# Altium schematic sheet 403.5MHz_LNA.SchDoc(403.5MHz_LNA)
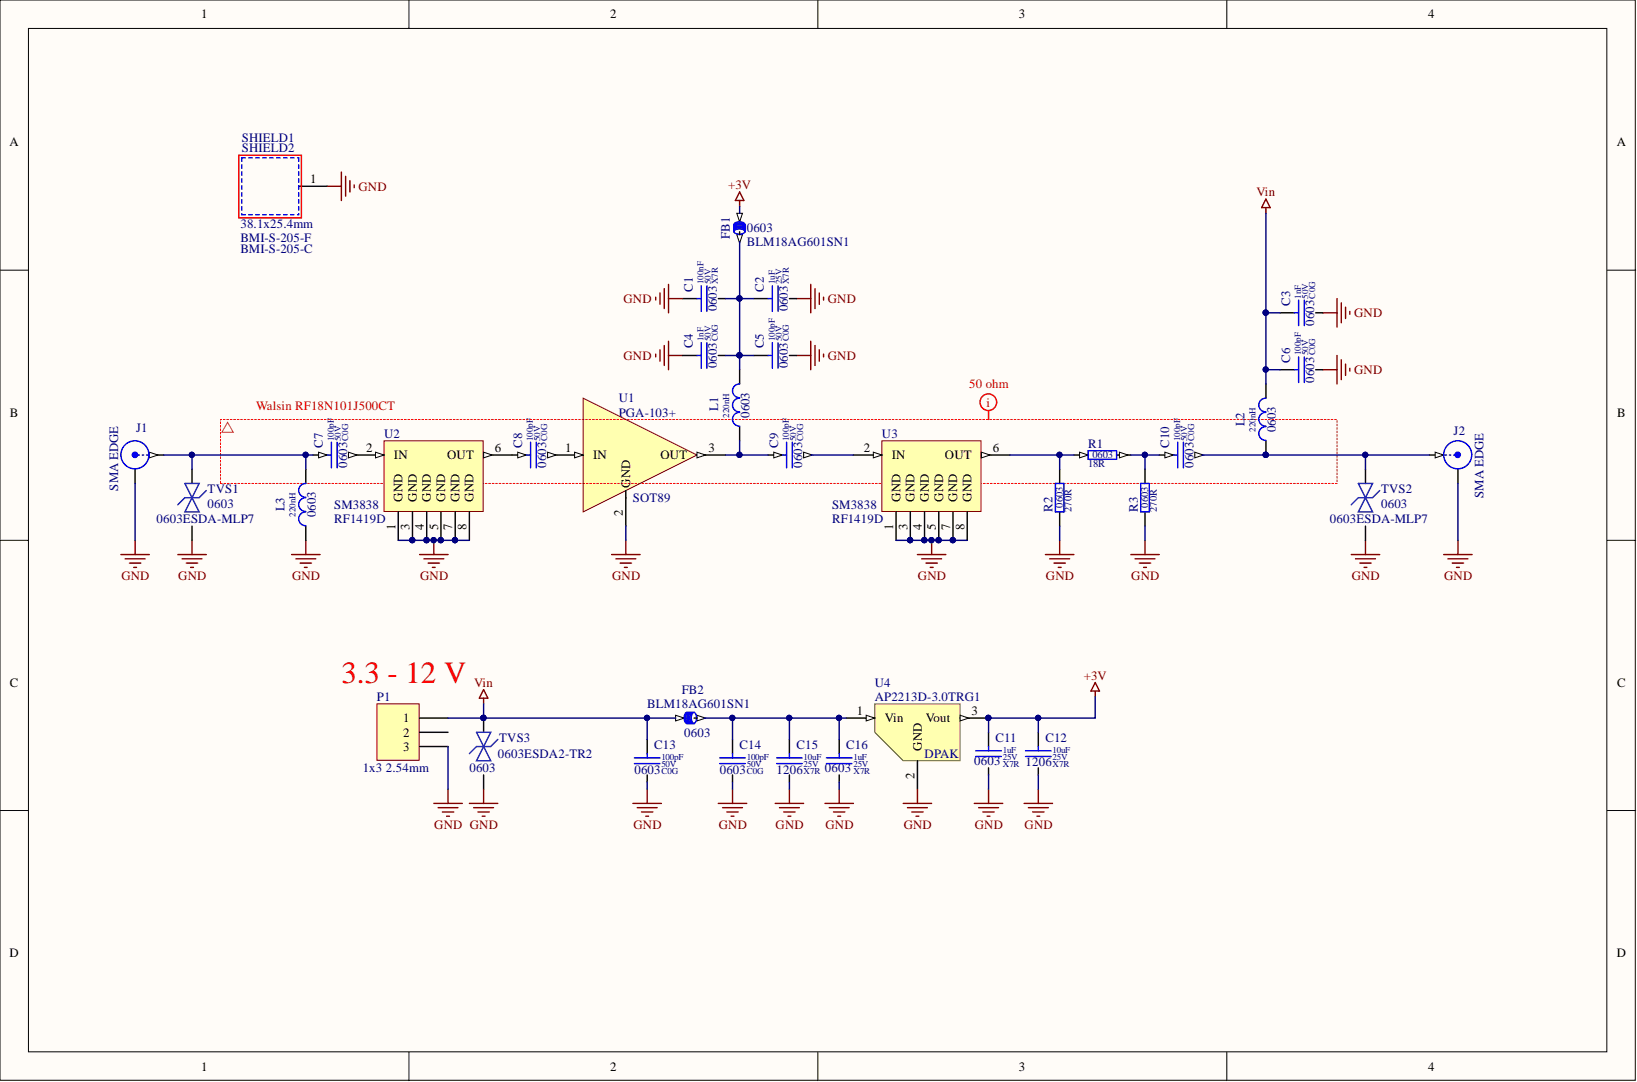
[diagram p0: 403.5MHz_LNA.SchDoc]

## Parts
C1  value 100nF  Voltage: 50V  SCH_Footprint: 0603  Dielectric: X7R  [C_0603_1608]  (hidden comment: Ceramic X7R)
C2  value 1uF  Voltage: 25V  SCH_Footprint: 0603  Dielectric: X7R  [C_0603_1608]  (hidden comment: Ceramic X7R)
C3  value 1nF  Voltage: 50V  SCH_Footprint: 0603  Dielectric: C0G  [C_0603_1608]  (hidden comment: Ceramic C0G)
C4  value 1nF  Voltage: 50V  SCH_Footprint: 0603  Dielectric: C0G  [C_0603_1608]  (hidden comment: Ceramic C0G)
C5  value 100pF  Voltage: 50V  SCH_Footprint: 0603  Dielectric: C0G  [C_0603_1608]  (hidden comment: Ceramic C0G)
C6  value 100pF  Voltage: 50V  SCH_Footprint: 0603  Dielectric: C0G  [C_0603_1608]  (hidden comment: Ceramic C0G)
C7  value 100pF  Voltage: 50V  SCH_Footprint: 0603  Dielectric: C0G  [C_0603_1608]  (hidden comment: Ceramic C0G)
C8  value 100pF  Voltage: 50V  SCH_Footprint: 0603  Dielectric: C0G  [C_0603_1608]  (hidden comment: Ceramic C0G)
C9  value 100pF  Voltage: 50V  SCH_Footprint: 0603  Dielectric: C0G  [C_0603_1608]  (hidden comment: Ceramic C0G)
C10  value 100pF  Voltage: 50V  SCH_Footprint: 0603  Dielectric: C0G  [C_0603_1608]  (hidden comment: Ceramic C0G)
C11  value 1uF  Voltage: 25V  SCH_Footprint: 0603  Dielectric: X7R  [C_0603_1608]  (hidden comment: Ceramic X7R)
C12  value 10uF  Voltage: 25V  SCH_Footprint: 1206  Dielectric: X7R  [C_1206_3216]  (hidden comment: Ceramic X7R)
C13  value 100pF  Voltage: 50V  SCH_Footprint: 0603  Dielectric: C0G  [C_0603_1608]  (hidden comment: Ceramic C0G)
C14  value 100pF  Voltage: 50V  SCH_Footprint: 0603  Dielectric: C0G  [C_0603_1608]  (hidden comment: Ceramic C0G)
C15  value 10uF  Voltage: 25V  SCH_Footprint: 1206  Dielectric: X7R  [C_1206_3216]  (hidden comment: Ceramic X7R)
C16  value 1uF  Voltage: 25V  SCH_Footprint: 0603  Dielectric: X7R  [C_0603_1608]  (hidden comment: Ceramic X7R)
FB1  value BLM18AG601SN1  SCH_Footprint: 0603  [FB_0603_1608]  (hidden comment: Ferrite bead)
FB2  value BLM18AG601SN1  SCH_Footprint: 0603  [FB_0603_1608]  (hidden comment: Ferrite bead)
J1  SMA EDGE  [J_SMA_EDGE_6.25]
J2  SMA EDGE  [J_SMA_EDGE_6.25]
L1  value 220nH  SCH_Footprint: 0603  [L_0603_1608]  (hidden comment: Inductor)
L2  value 220nH  SCH_Footprint: 0603  [L_0603_1608]  (hidden comment: Inductor)
L3  value 220nH  SCH_Footprint: 0603  [L_0603_1608]  (hidden comment: Inductor)
P1  SCH_Footprint: 1x3 2.54mm  [P_HEADER_1x3_2.54]  (hidden comment: Goldpin)
R1  value 18R  SCH_Footprint: 0603  [R_NC_0603_1608]  (hidden comment: Resistor)
R2  value 270R  SCH_Footprint: 0603  [R_NC_0603_1608]  (hidden comment: Resistor)
R3  value 270R  SCH_Footprint: 0603  [R_NC_0603_1608]  (hidden comment: Resistor)
SHIELD1  value BMI-S-205-C  SCH_Footprint: 38.1x25.4mm  [BMI-S-205-C]  (hidden comment: EMI Shield Cover)
SHIELD2  value BMI-S-205-F  SCH_Footprint: 38.1x25.4mm  [BMI-S-205-F]  (hidden comment: EMI Shield)
TVS1  value 0603ESDA-MLP7  SCH_Footprint: 0603  [R_0603_1608]  (hidden comment: TVS bidirectional)
TVS2  value 0603ESDA-MLP7  SCH_Footprint: 0603  [R_0603_1608]  (hidden comment: TVS bidirectional)
TVS3  0603ESDA2  value 0603ESDA2-TR2  SCH_Footprint: 0603  [R_0603_1608]
U1  PGA103+  value PGA-103+  SCH_Footprint: SOT89  [SOT89_TO-243AA_N]
U2  RF1419D  SCH_Footprint: SM3838  [SAW_QCC8B_SM3838-8_3.8x3.8]
U3  RF1419D  SCH_Footprint: SM3838  [SAW_QCC8B_SM3838-8_3.8x3.8]
U4  AP2213D-3.0  value AP2213D-3.0TRG1  SCH_Footprint: DPAK  [DPAK_TO252-3_SC63_M]

## Nets
+3V: C11.1 C12.1 FB1.1 U4.3
GND: C1.1 C2.2 C3.2 C4.1 C5.2 C6.2 C11.2 C12.2 C13.2 C14.2 C15.2 C16.2 J1.2 J2.2 L3.1 P1.3 R2.1 R3.1 SHIELD2.1 TVS1.2 TVS2.2 TVS3.2 U1.2 U2.1(GND) U2.3(GND) U2.4(GND) U2.5(GND) U2.7(GND) U2.8(GND) U3.1(GND) U3.3(GND) U3.4(GND) U3.5(GND) U3.7(GND) U3.8(GND) U4.2
Vin: C3.1 C6.1 C13.1 FB2.1 L2.2 P1.1 TVS3.1
NetC1_2: C1.2 C2.1 C4.2 C5.1 FB1.2 L1.2
NetC7_1: C7.1 J1.1 L3.2 TVS1.1
NetC7_2: C7.2 U2.2(IN)
NetC8_1: C8.1 U2.6(OUT)
NetC8_2: C8.2 U1.1
NetC9_1: C9.1 L1.1 U1.3
NetC9_2: C9.2 U3.2(IN)
NetC10_1: C10.1 R1.2 R3.2
NetC10_2: C10.2 J2.1 L2.1 TVS2.1
NetC14_1: C14.1 C15.1 C16.1 FB2.2 U4.1
NetR1_1: R1.1 R2.2 U3.6(OUT)
N00003:

Not connected: P1 2

## Library data of the parts
C1 = C_100nF_50V_X7R_0603_5: Capacitor; Manufacturer Part Number: CL10B104JB8NNNC; Manufacturer: Samsung; Tolerance (%): 5; Database Table Name: Capacitors; Supplier Part Number 1: CL10B104JB8NNNC; Supplier 5: Digi-Key; Supplier 4: Mouser; Supplier 3: Farnell; Supplier 2: RS Components; Supplier 1: TME; Part Number: C_100nF_50V_X7R_0603_5; Library Name: Library.DBLib; HelpURL: http://www.tme.eu/pl/Document/4a42202b32dab16128fe107dd69598cc/samsung-chip-cap.pdf; Component Kind: Standard
C2 C11 C16 = C_1uF_25V_X7R_0603_10: Capacitor; Manufacturer Part Number: CL10B105KA8NNNC; Manufacturer: Samsung; Tolerance (%): 10; Database Table Name: Capacitors; Supplier Part Number 1: CL10B105KA8NNNC; Supplier 5: Digi-Key; Supplier 4: Mouser; Supplier 3: Farnell; Supplier 2: RS Components; Supplier 1: TME; Part Number: C_1uF_25V_X7R_0603_10; Library Name: Library.DBLib; HelpURL: http://www.tme.eu/pl/Document/4a42202b32dab16128fe107dd69598cc/samsung-chip-cap.pdf; Component Kind: Standard
C3 C4 = C_1nF_50V_C0G_0603_5: Capacitor; Manufacturer Part Number: CL10C102JB8NNNC; Manufacturer: Samsung; Tolerance (%): 5; Database Table Name: Capacitors; Supplier Part Number 1: CL10C102JB8NNNC; Supplier 5: Digi-Key; Supplier 4: Mouser; Supplier 3: Farnell; Supplier 2: RS Components; Supplier 1: TME; Part Number: C_1nF_50V_C0G_0603_5; Library Name: Library.DBLib; HelpURL: http://www.tme.eu/pl/Document/7da762c1dbaf553c64ad9c40d3603826/mlcc_samsung.pdf; Component Kind: Standard
C5-C10 C13 C14 = C_100pF_50V_C0G_0603_5: Capacitor; Manufacturer Part Number: RF18N101J500CT; Manufacturer: Walsin; Tolerance (%): 5; Database Table Name: Capacitors; Supplier 5: Digi-Key; Supplier 4: Mouser; Supplier 3: Farnell; Supplier 2: RS Components; Supplier 1: TME; Part Number: C_100pF_50V_C0G_0603_5; Library Name: Library.DBLib; HelpURL: https://pl.mouser.com/datasheet/2/210/Microwave_Caps-1535561.pdf; Component Kind: Standard
C12 C15 = C_10uF_25V_X7R_1206_10: Capacitor; Manufacturer Part Number: CL31B106KAHNNNE; Manufacturer: Samsung Electro-Mechanics; Tolerance (%): 10; Database Table Name: Capacitors; Supplier Part Number 1: CL31B106KAHNNNE; Supplier 5: Digi-Key; Supplier 4: Mouser; Supplier 3: Farnell; Supplier 2: RS Components; Supplier 1: TME; Part Number: C_10uF_25V_X7R_1206_10; Library Name: Library.DBLib; HelpURL: http://www.tme.eu/pl/Document/4a42202b32dab16128fe107dd69598cc/samsung-chip-cap.pdf; Component Kind: Standard
FB1 FB2 = FB_BLM18AG601SN1: Manufacturer Part Number: BLM18AG601SN1D; Manufacturer: Murata; Resistance: 600R; Database Table Name: Inductors; Current: 0A5; Supplier Part Number 5: 490-1014-1-ND; Supplier Part Number 4: 81-BLM11A601S; Supplier Part Number 3: 1515679; Supplier Part Number 2: 7241299P; Supplier 5: Digi-Key; Supplier 4: Mouser; Supplier 3: Farnell; Supplier 2: RS Components; Supplier 1: TME; Part Number: FB_BLM18AG601SN1; Library Name: Library.DBLib; HelpURL: http://datasheet.octopart.com/BLM18AG601SN1D-Murata-datasheet-11743096.pdf; Description: Ferrite Bead; Component Kind: Standard
J1 J2 = J_SMA_EDGE_6.25: SMA connector; Manufacturer: Adam Tech; Value: RF2-143-T-17-50-G; Database Table Name: Connectors; Supplier Part Number 1: RF2-143-T-17-50-G; Supplier 5: Digi-Key; Supplier 4: Mouser; Supplier 3: Farnell; Supplier 2: RS Components; Supplier 1: TME; SCH_Footprint: SMA EDGE; Part Number: J_SMA_EDGE_6.25; Manufacturer Part Number: RF2-143-T-17-50-G; Library Name: Library.DBLib; HelpURL: http://www.tme.eu/pl/Document/5895dbb620da9c3499391e7670c4adea/RF2-143-T-17-50-G.pdf; Component Type: Standard; Component Kind: Standard
L1-L3 = L_220nH_120mA_0603: Induction; Manufacturer Part Number: LQW18ANR22G00D; Manufacturer: Murata; Resistance: 2.5R; Database Table Name: Inductors; Current: 120mA; Supplier Part Number 5: 490-6931-1-ND; Supplier Part Number 4: 81-LQW18ANR22G00D; Supplier Part Number 3: 9528059; Supplier Part Number 2: 7242872; Supplier Part Number 1: LQW18ANR22G00D; Supplier 5: Digi-Key; Supplier 4: Mouser; Supplier 3: Farnell; Supplier 2: RS Components; Supplier 1: TME; Part Number: L_220nH_120mA_0603; Library Name: Library.DBLib; HelpURL: https://datasheet.octopart.com/LQW18ANR22G00D-Murata-datasheet-11553032.pdf; Component Kind: Standard
P1 = P_HEADER_1x3_2.54: Header, 3-Pin; Manufacturer: Connfly; Value: DS1021-1*3SF1-1; Database Table Name: Connectors; Supplier Part Number 1: ZL201-03G; Supplier 5: Digi-Key; Supplier 4: Mouser; Supplier 3: Farnell; Supplier 2: RS Components; Supplier 1: TME; Part Number: P_HEADER_1x3_2.54; Manufacturer Part Number: DS1021-1*3SF1-1; Library Name: Library.DBLib; HelpURL: http://www.tme.eu/en/Document/cc301e58a5da78cb543a94b5a5b7f06a/DS1021.pdf; Component Type: Standard; Component Kind: Standard
R1-R3 = R_GENERIC_0603: Database Table Name: Resistors; Supplier 5: Digi-Key; Supplier 4: Mouser; Supplier 3: Farnell; Supplier 2: RS Components; Supplier 1: TME; Part Number: R_GENERIC_0603; Library Name: Library.DBLib; Component Kind: Standard
SHIELD1 = SHIELD_BMI-S-205-C: Shield, 1 pad; Manufacturer: Laird; Database Table Name: Passive Components; Supplier Part Number 5: 903-1017-ND; Supplier Part Number 4: 739-BMIS-205-C; Supplier Part Number 3: 2497722; Supplier 5: Digi-Key; Supplier 4: Mouser; Supplier 3: Farnell; Supplier 2: RS Components; Supplier 1: TME; Part Number: SHIELD_BMI-S-205-C; Manufacturer Part Number: BMI-S-205-C; Library Name: Library.DBLib; HelpURL: https://assets.lairdtech.com/home/brandworld/files/Board%20Level%20Shields%20Catalog%20Download.pdf; Component Kind: Standard
SHIELD2 = SHIELD_BMI-S-205-F: Shield, 1 pad; Manufacturer: Laird; Database Table Name: Passive Components; Supplier Part Number 5: 903-1054-1-ND; Supplier Part Number 4: 739-BMIS-205-F; Supplier Part Number 3: 2497723; Supplier 5: Digi-Key; Supplier 4: Mouser; Supplier 3: Farnell; Supplier 2: RS Components; Supplier 1: TME; Part Number: SHIELD_BMI-S-205-F; Manufacturer Part Number: BMI-S-205-F; Library Name: Library.DBLib; HelpURL: https://assets.lairdtech.com/home/brandworld/files/Board%20Level%20Shields%20Catalog%20Download.pdf; Component Kind: Standard
TVS1 TVS2 = TVS_0603ESDA-MLP7: Manufacturer: Bussmann; Voltage: 30V; Database Table Name: Diodes; Supplier 5: Digi-Key; Supplier 4: Mouser; Supplier 3: Farnell; Supplier 2: RS Components; Supplier 1: TME; Part Number: TVS_0603ESDA-MLP7; Manufacturer Part Number: 0603ESDA-MLP7; Library Name: Library.DBLib; HelpURL: https://datasheet.octopart.com/0603ESDA-MLP7-Eaton-datasheet-12528739.pdf; Component Kind: Standard
TVS3 = TVS_0603ESDA2: Manufacturer: Bussmann; Voltage: 14V; Database Table Name: Diodes; Current: 30A; Supplier Part Number 5: 283-4156-1-ND; Supplier Part Number 4: 504-0603ESDA2-TR2; Supplier Part Number 1: 0603ESDA2-TR2; Supplier 5: Digi-Key; Supplier 4: Mouser; Supplier 3: Farnell; Supplier 2: RS Components; Supplier 1: TME; Part Number: TVS_0603ESDA2; Manufacturer Part Number: 0603ESDA2-TR2; Library Name: Library.DBLib; HelpURL: http://www.cooperindustries.com/content/dam/public/bussmann/Electronics/Resources/product-datasheets/bus-elx-ds-4419-0603esda2-tr2.pdf; Component Kind: Standard
U1 = U_PGA103+: Manufacturer: Mini-Circuits; Database Table Name: IC Other; Supplier 5: Digi-Key; Supplier 4: Mouser; Supplier 3: Farnell; Supplier 2: RS Components; Supplier 1: TME; Part Number: U_PGA103+; Manufacturer Part Number: PGA-103+; Library Name: Library.DBLib; HelpURL: https://ww2.minicircuits.com/pdfs/PGA-103+.pdf; Component Kind: Standard
U2 U3 = U_RF1419D: Manufacturer: Murata; Max Power (dBm): 10; Database Table Name: SAW; Center Frequency (MHz): 403.5; Bandwidth (MHz): 7.5; Value: RF1419D; Supplier Part Number 5: 583-1065-1-ND; Supplier Part Number 4: 719-RF1419D; Supplier Part Number 2: 9082736; Supplier 5: Digi-Key; Supplier 4: Mouser; Supplier 3: Farnell; Supplier 2: RS Components; Supplier 1: TME; Part Number: U_RF1419D; Manufacturer Part Number: RF1419D; Library Name: Library.DBLib; HelpURL: https://wireless.murata.com/datasheet?/RFM/data/rf1419d.pdf; Component Kind: Standard
U4 = VL_AP2213D-3.0TRG1: Manufacturer: Diodes Inc; Voltage: 3V; Database Table Name: Voltage Regulators; Current: 0.5A; Supplier Part Number 5: AP2213D-3.0TRG1-ND; Supplier Part Number 4: 621-AP2213D-3.0TRG1; Supplier 5: Digi-Key; Supplier 4: Mouser; Supplier 3: Farnell; Supplier 2: RS Components; Supplier 1: TME; Part Number: VL_AP2213D-3.0TRG1; Manufacturer Part Number: AP2213D-3.0TRG1; Library Name: Library.DBLib; HelpURL: https://pl.mouser.com/datasheet/2/115/AP2213-318105.pdf; Component Kind: Standard
